# Altium schematic sheet 01.W7500P.SchDoc
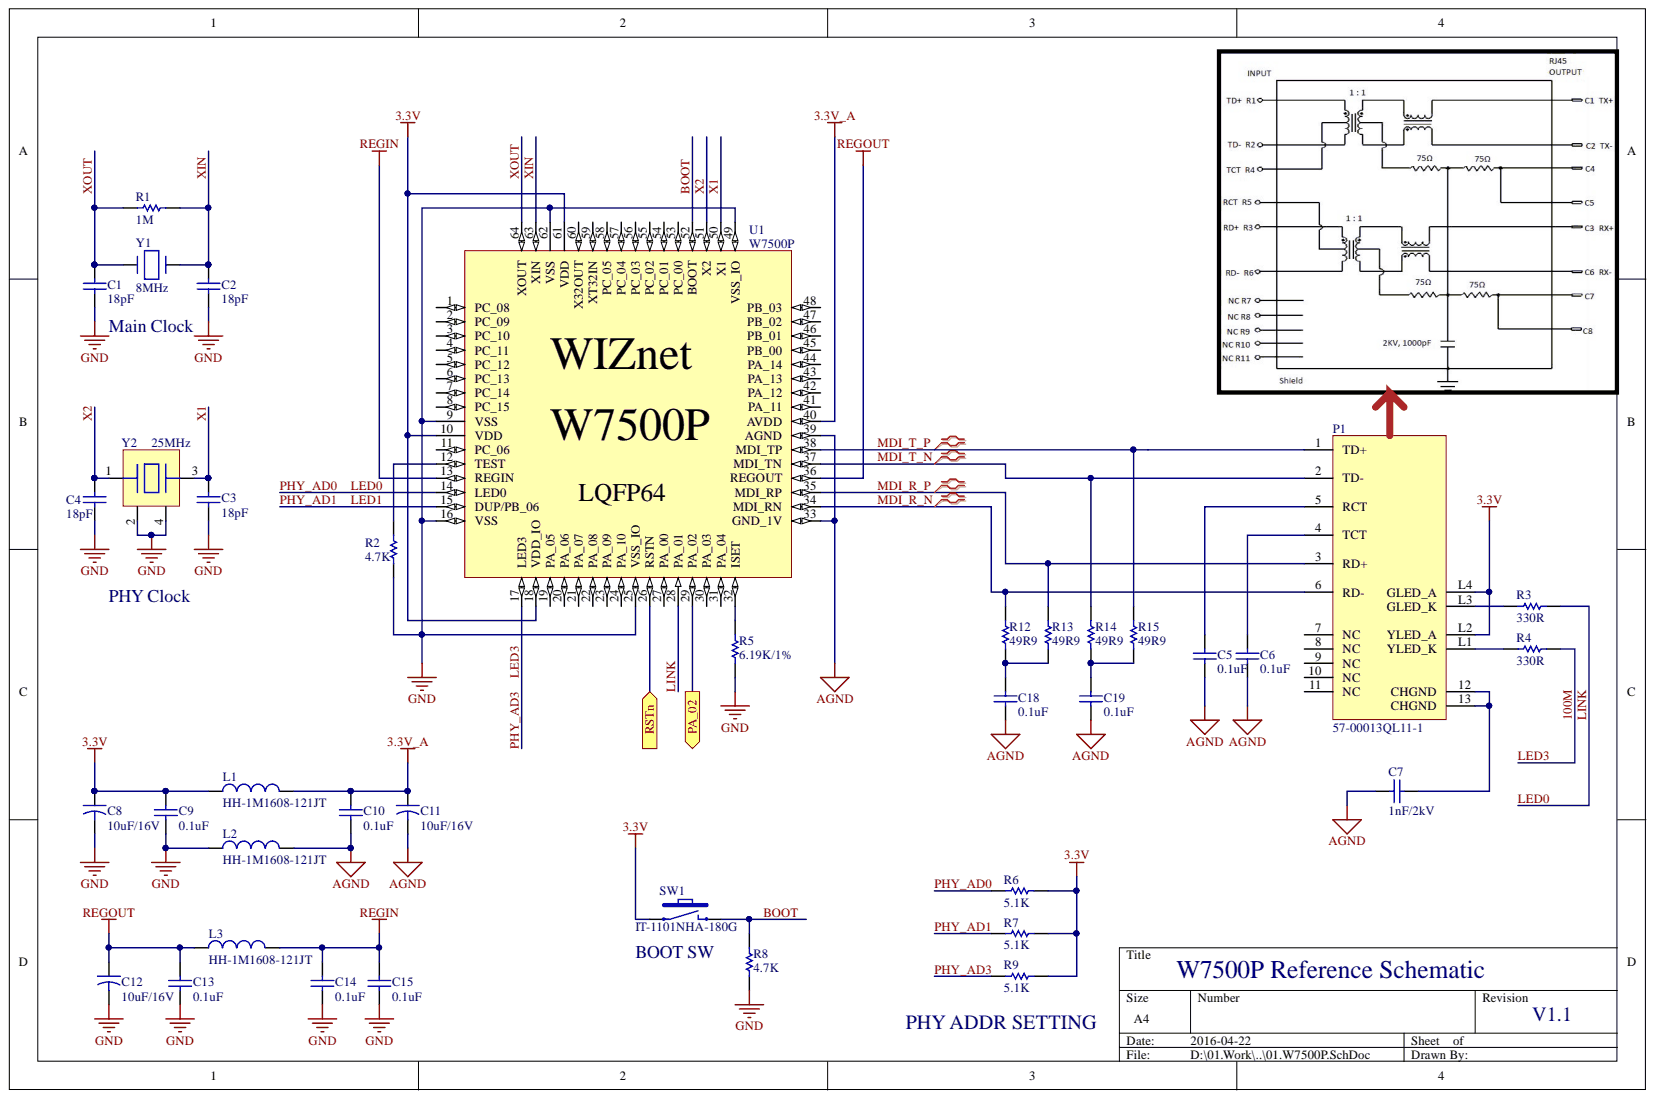
[diagram p0: 01.W7500P.SchDoc]

## Parts
C1  18pF  [CAP-0603]
C2  18pF  [CAP-0603]
C3  18pF  [CAP-0603]
C4  18pF  [CAP-0603]
C5  0.1uF  [CAP-0603]
C6  0.1uF  [CAP-0603]
C7  1nF/2kV  [CAP-1808]
C8  10uF/16V  [CAP-A Size]
C9  0.1uF  [CAP-0603]
C10  0.1uF  [CAP-0603]
C11  10uF/16V  [CAP-A Size]
C12  10uF/16V  [CAP-A Size]
C13  0.1uF  [CAP-0603]
C14  0.1uF  [CAP-0603]
C15  0.1uF  [CAP-0603]
C18  0.1uF  [CAP-0603]
C19  0.1uF  [CAP-0603]
L1  HH-1M1608-121JT  [IND-0603]
L2  HH-1M1608-121JT  [IND-0603]
L3  HH-1M1608-121JT  [IND-0603]
P1  57-00013QL11-1  [RJ45-57-00013QL11-1]
R1  1M  [RES-0603]
R2  4.7K  [RES-0603]
R3  330R  [RES-0603]
R4  330R  [RES-0603]
R5  6.19K/1%  [RES-0603]
R6  5.1K  [RES-0603]
R7  5.1K  [RES-0603]
R8  4.7K  [RES-0603]
R9  5.1K  [RES-0603]
R12  49R9  [RES-0603]
R13  49R9  [RES-0603]
R14  49R9  [RES-0603]
R15  49R9  [RES-0603]
SW1  IT-1101NHA-180G  [IT-1101NHA]
U1  W7500P  [W7500P-LQFP64]
Y1  8MHz  [OSC-ATS Type]
Y2  25MHz  [OSC-TZ1067B]

## Ports
PA_02, RSTN

## Nets
3.3V: C8.1 C9.2 L1.1 P1.L2(YLED_A) P1.L4(GLED_A) R6.1 R7.1 R9.1 SW1.1 U1.10(VDD) U1.18(VDD_IO) U1.61(VDD)
3.3V_A: C10.2 C11.1 L1.2 U1.40(AVDD)
AGND: C5.1 C6.1 C7.2 C10.1 C11.2 C18.1 C19.1 L2.2 U1.33(GND_1V) U1.39(AGND)
GND: C1.1 C2.1 C3.1 C4.1 C8.2 C9.1 C12.2 C13.1 C14.1 C15.1 L2.1 R2.1 R5.1 R8.1 U1.9(VSS) U1.16(VSS) U1.25(VSS_IO) U1.49(VSS_IO) U1.62(VSS) Y2.2 Y2.4
REGIN: C14.2 C15.2 L3.2 U1.13(REGIN)
REGOUT: C12.1 C13.2 L3.1 U1.36(REGOUT)
100M: R4.1 R9.2 U1.17(LED3)
BOOT: R8.2 SW1.2 U1.52(BOOT)
LED0: R3.1 R6.2 U1.14(LED0) U1.28(PA_01)
LED1: R7.2 U1.15(DUP/PB_06)
MDI_R_N: P1.6(RD-) R12.2 U1.34(MDI_RN)
MDI_R_P: P1.3(RD+) R13.2 U1.35(MDI_RP)
MDI_T_N: P1.2(TD-) R14.2 U1.37(MDI_TN)
MDI_T_P: P1.1(TD+) R15.2 U1.38(MDI_TP)
PA_02: U1.29(PA_02) ; port PA_02
X1: C3.2 U1.50(X1) Y2.3
X2: C4.2 U1.51(X2) Y2.1
XIN: C2.2 R1.2 U1.63(XIN) Y1.2
XOUT: C1.2 R1.1 U1.64(XOUT) Y1.1
NetC5_2: C5.2 P1.5(RCT)
NetC6_2: C6.2 P1.4(TCT)
NetC7_1: C7.1 P1.12(CHGND) P1.13(CHGND)
NetC18_2: C18.2 R12.1 R13.1
NetC19_2: C19.2 R14.1 R15.1
NetP1_L1: P1.L1(YLED_K) R4.2
NetP1_L3: P1.L3(GLED_K) R3.2
NetR2_2: R2.2 U1.12(TEST)
NetR5_2: R5.2 U1.32(ISET)
NetU1_26: U1.26(RSTN) ; port RSTN

Not connected: P1 7(NC) 8(NC) 9(NC) 10(NC) 11(NC); U1 1(PC_08) 2(PC_09) 3(PC_10) 4(PC_11) 5(PC_12) 6(PC_13) 7(PC_14) 8(PC_15) 11(PC_06) 19(PA_05) 20(PA_06) 21(PA_07) 22(PA_08) 23(PA_09) 24(PA_10) 27(PA_00) 30(PA_03) 31(PA_04) 41(PA_11) 42(PA_12) 43(PA_13) 44(PA_14) 45(PB_00) 46(PB_01) 47(PB_02) 48(PB_03) 53(PC_00) 54(PC_01) 55(PC_02) 56(PC_03) 57(PC_04) 58(PC_05) 59(XT32IN) 60(X32OUT)

## Library data of the parts
C1-C6 C9 C10 C13-C15 C18 C19 = CAP-0603: Ceramic CAP 0603(1608); Component Kind: Standard; Library Name: Scott_lib.IntLib
C7 = CAP-1808: Ceramic CAP 1808(4520); Component Kind: Standard; Library Name: Scott_lib.IntLib
C8 C11 C12 = CAP-A Size: Tantla CAP A Size EIA/IECQ 3216; Component Kind: Standard; Library Name: Scott_lib.IntLib
L1-L3 = IND-0603: Inductor 0603(1608); Component Kind: Standard; Library Name: Scott_lib.IntLib
R1-R9 R12-R15 = RES-0603: Component Kind: Standard; Description: RES-0603; Library Name: Scott_lib.IntLib
SW1 = IT-1101NHA-180G: TACT Switch (SMD); Component Kind: Standard; Library Name: Scott_lib.IntLib
U1 = W7500P: WIZnet ARM Cortex-M0, Add PHY; Component Kind: Standard; Library Name: Scott_lib.IntLib
Y1 = OSC-ATS Type: ATS Type Crystal; Component Kind: Standard; Library Name: Scott_lib.IntLib
Y2 = OSC-82J0626-25MHz: X-tal 25MHz,3.2x2.5 SMD, CL=18pF; Component Kind: Standard; Library Name: Scott_lib.IntLib
P1 = 57-00013QL11-1: Component Kind: Standard; Library Name: Scott_lib.IntLib
